# Altium schematic sheet 07_Module_Power.SchDoc
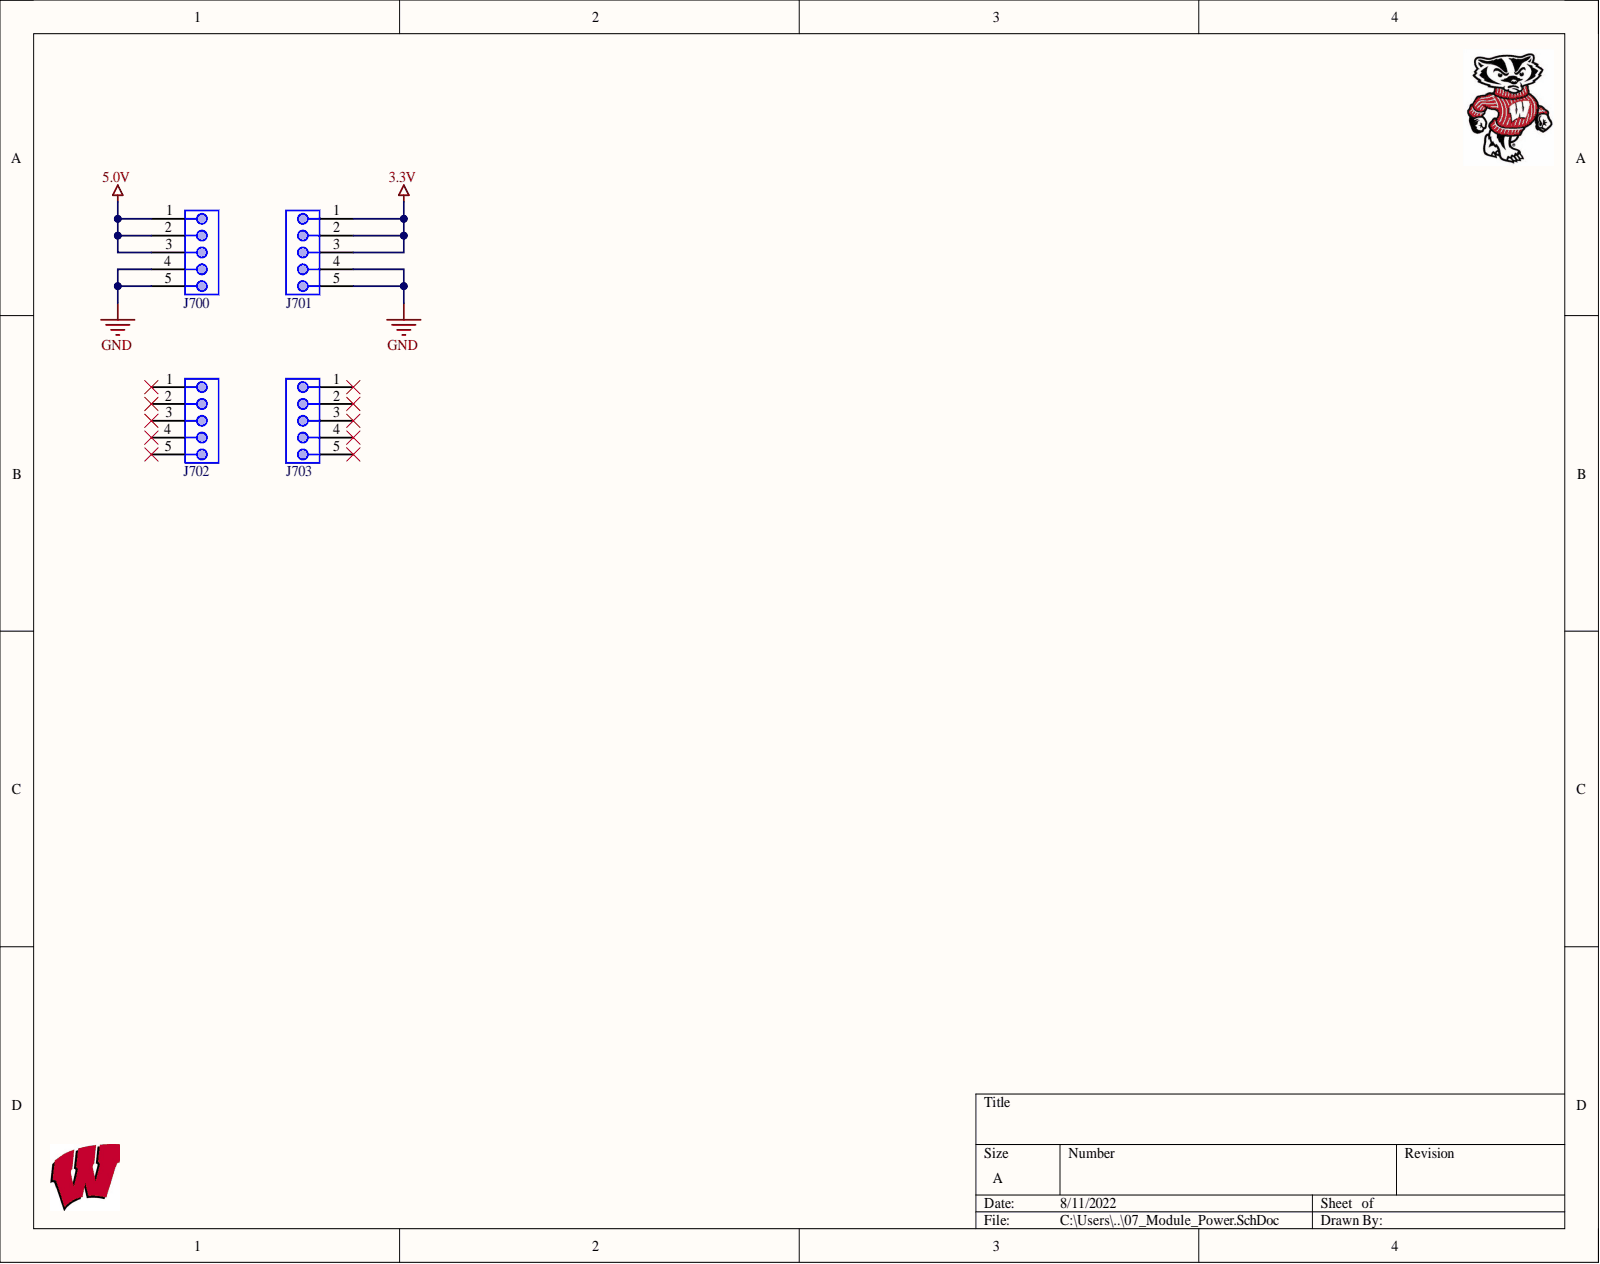
[diagram p0: 07_Module_Power.SchDoc]

## Parts
J700  CONN_HEADER_MALE_1x5  [HDR1X5]  (value not drawn)
J701  CONN_HEADER_MALE_1x5  [HDR1X5]  (value not drawn)
J702  CONN_HEADER_MALE_1x5  [HDR1X5]  (value not drawn)
J703  CONN_HEADER_MALE_1x5  [HDR1X5]  (value not drawn)

## Nets
3.3V: J701.1 J701.2 J701.3
5.0V: J700.1 J700.2 J700.3
GND: J700.4 J700.5 J701.4 J701.5

Not connected: J702 1 2 3 4 5; J703 1 2 3 4 5

## Library data of the parts
J700-J703 = CONN_HEADER_MALE_1x5: Header, 5-Pin; Manufacturer 1: Sullins; Manufacturer Part Number 1: PREC005SACN-RC; Connector Type: Male Pin; Number of Positions: 5; Pitch: 0.1inch; Color: Black; Component Kind: Standard; ComponentLink1Description: http://www.farnell.com/cad/1737268.pdf; ComponentLink1URL: http://www.farnell.com/cad/1737268.pdf; ComponentLink2Description: http://katalog.we-online.de/em/datasheet/6130xx11121.pdf; ComponentLink2URL: http://katalog.we-online.de/em/datasheet/6130xx11121.pdf; ComponentLink3Description: https://datasheet.ciiva.com/741/xrxczzzsxxn-rc-st-11635-b-741840.pdf?src-supplier=Digi-Key; ComponentLink3URL: https://datasheet.ciiva.com/741/xrxczzzsxxn-rc-st-11635-b-741840.pdf?src-supplier=Digi-Key; Contact Mating Length: 0.23inch; Contact Plating: Tin; Library Name: Connectors.SchLib; Mount: Through Hole; Number of Rows: 1; Package Quantity: 1; Packaging: Bulk; Pricing 1: 1=0.19000, 10=0.17400, 25=0.12960, 50=0.11120, 100=0.10740, 250=0.09264, 500=0.08892 (USD); RoHS: Yes; Stock 1: 0; Supplier 1: Digi-Key; Supplier Part Number 1: S1032EC-05-ND; Termination: Solder
